# Altium schematic sheet TF.SchDoc(TF)
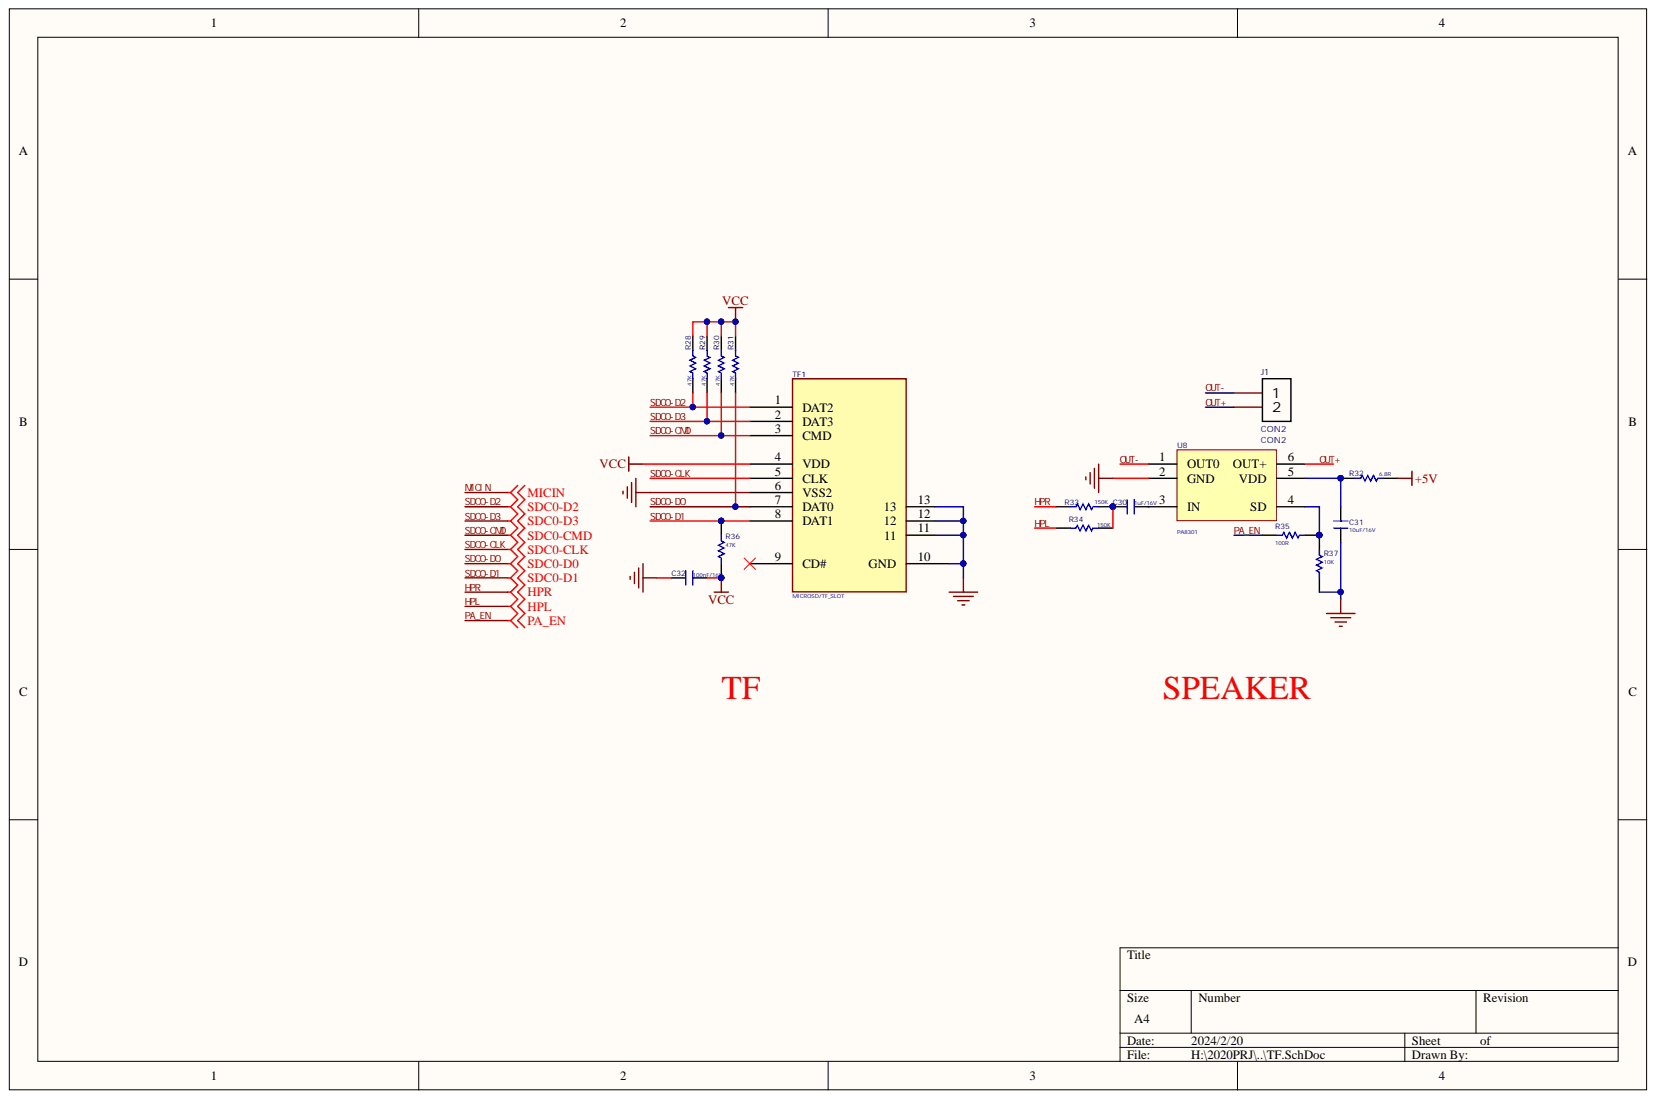
[diagram p0: TF.SchDoc]

## Parts
C30  1uF/16V  [C0402]
C31  10uF/16V  [C0402]
C32  100nF/16V  [C0402]
J1  CON2  [SIP-2P-SMT]
R28  47K  [R0402]
R29  47K  [R0402]
R30  47K  [R0402]
R31  47K  [R0402]
R32  6.8R  [R0402]
R33  150K  [R0402]
R34  150K  [R0402]
R35  100R  [R0402]
R36  47K  [R0402]
R37  10K  [R0402]
TF1  MICROSD/TF_SLOT  [TFCARD]
U8  PA8301  [CSOT23-6]

## Nets
+5V: R32.2
GND: C31.1 C32.1 R37.1 TF1.6(VSS2) TF1.10(GND) TF1.11 TF1.12 TF1.13 U8.2(GND)
VCC: C32.2 R28.1 R29.1 R30.1 R31.1 R36.2 TF1.4(VDD)
HPL: R34.1
HPR: R33.1
OUT+: J1.2 U8.6(OUT+)
OUT-: J1.1 U8.1(OUT0)
PA_EN: R35.2
SDC0-CLK: TF1.5(CLK)
SDC0-CMD: R30.2 TF1.3(CMD)
SDC0-D0: R31.2 TF1.7(DAT0)
SDC0-D1: R36.1 TF1.8(DAT1)
SDC0-D2: R28.2 TF1.1(DAT2)
SDC0-D3: R29.2 TF1.2(DAT3)
NetC30_1: C30.1 R33.2 R34.2
NetC30_2: C30.2 U8.3(IN)
NetC31_2: C31.2 R32.1 U8.5(VDD)
NetR35_1: R35.1 R37.2 U8.4(SD)
MICIN:

Not connected: TF1 9(CD#)

## Library data of the parts
C30-C32 = CAPX: 贴片电容100nF/25V_0402; Component Kind: Standard
J1 = CON2: Connector; Add into BOM: yes; Origin Footprint: SIP2_2.54; Symbol: con2_2; Component Kind: Standard; Convert to PCB: yes; Device: CON2; Name: CON2
R28-R37 = res1: 贴片电阻100K_0402; Value: 1K; Component Kind: Standard; LatestRevisionDate: 17-Jul-2002; LatestRevisionNote: Re-released for DXP Platform.; Library Name: Miscellaneous Devices.IntLib; PackageDescription: Axial Device, Thru-Hole; 2 Leads; 0.3 in Pin Spacing; PackageReference: AXIAL-0.3; Published: 8-Jun-2000; Signal Integrity: Res; Simulation: RESISTOR; Publisher: Altium Limited
TF1 = SDMMC SLOT_11: Component Kind: Standard; Library Name: EU7013.SCHLIB
U8 = PA8301: Component Kind: Standard; Library Name: Schlib1.SchLib
